# Altium schematic sheet SheetV5.SchDoc
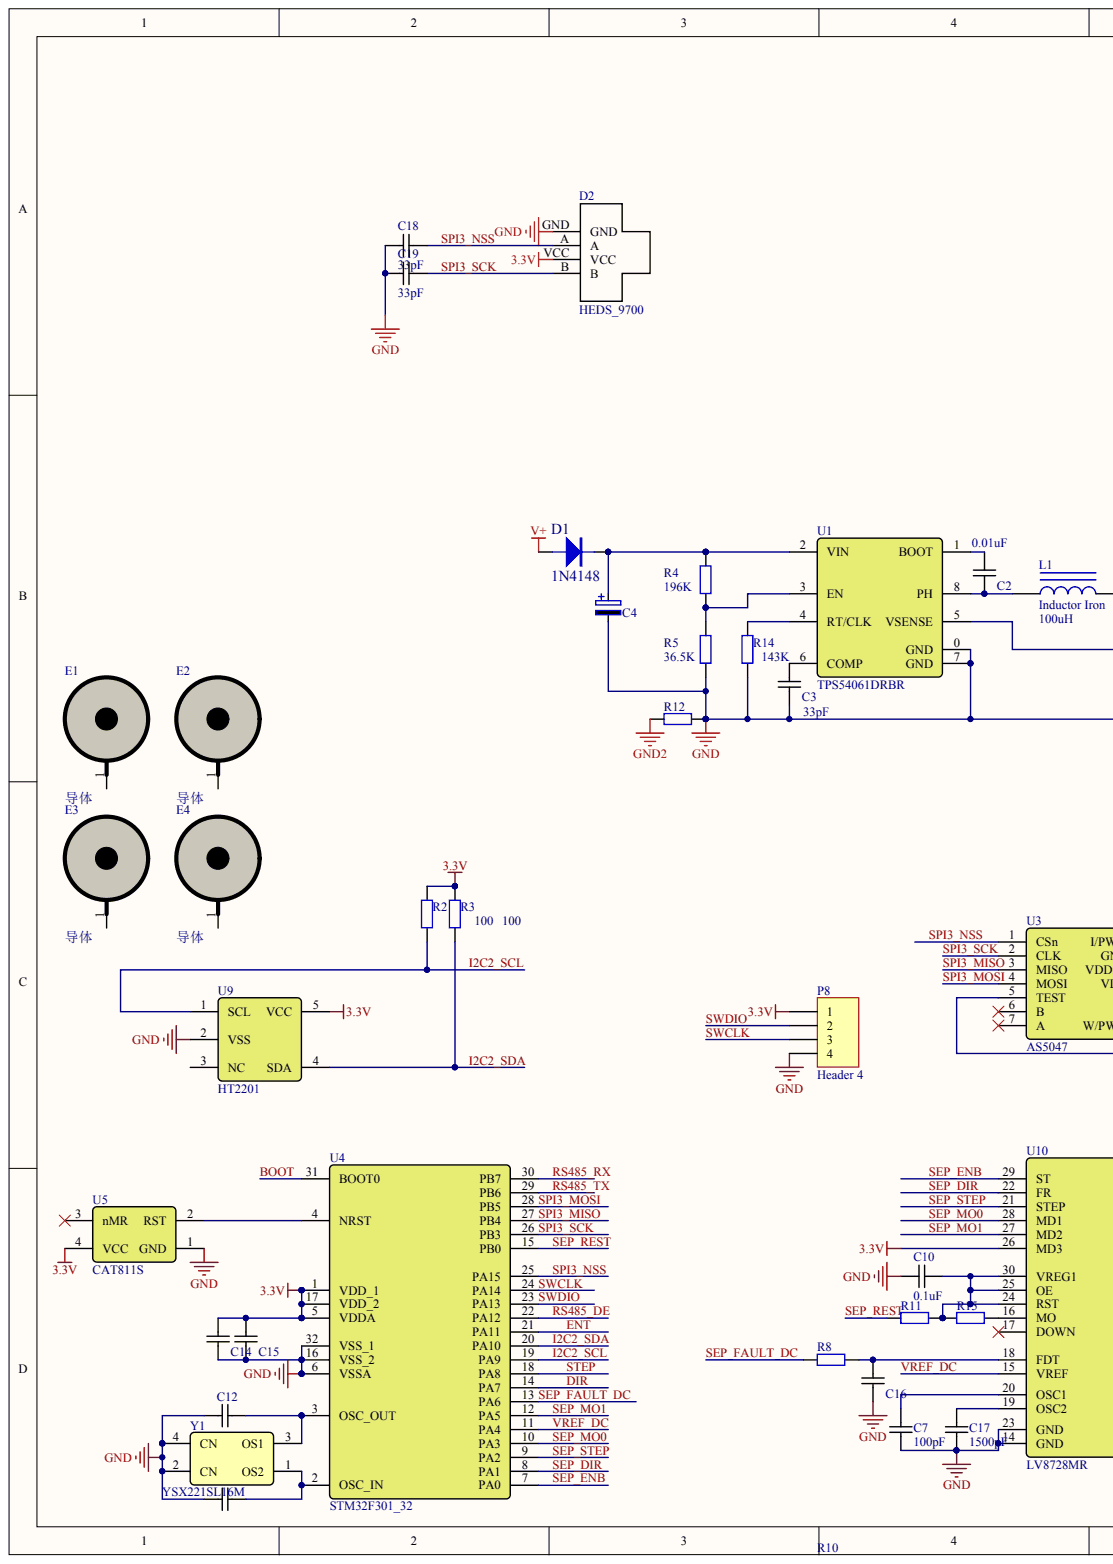
[diagram p0: SheetV5.SchDoc, left]
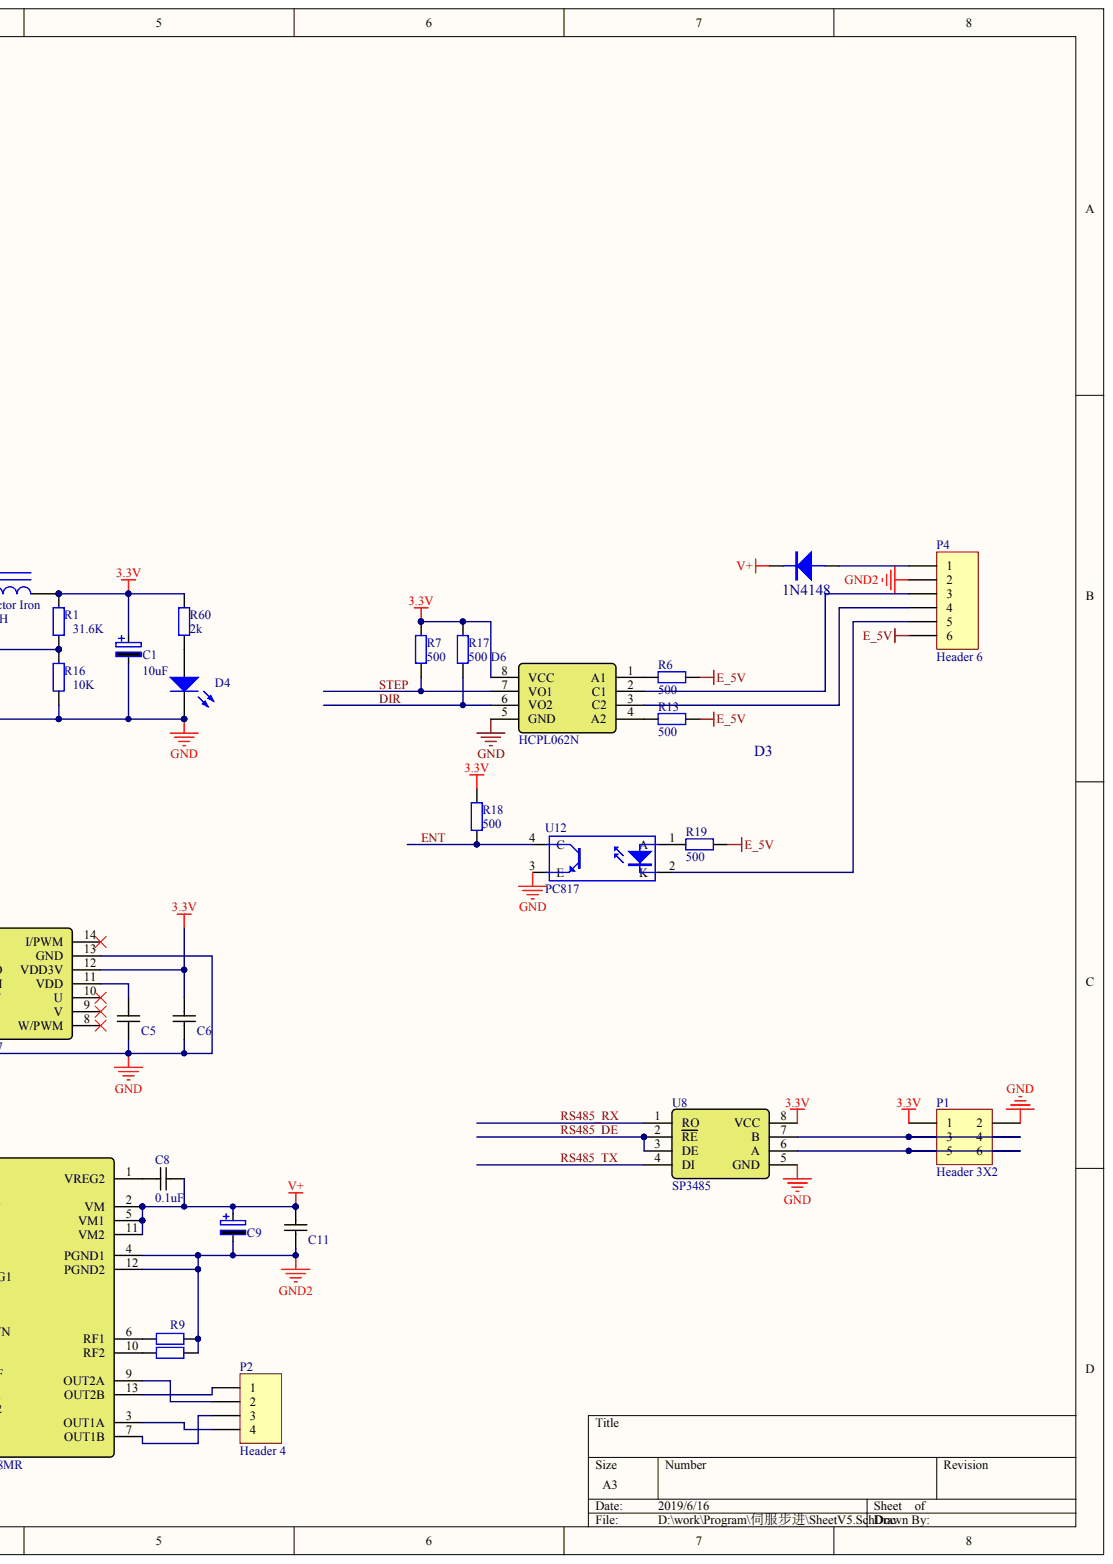
[diagram p1: SheetV5.SchDoc, right]

## Parts
C1  10uF
C2  0.01uF
C3  33pF
C4  100uF  (value not drawn)
C5  Cap  (value not drawn)
C6  Cap  (value not drawn)
C7  100pF
C8  0.1uF
C9  100uF  (value not drawn)
C10  0.1uF
C11  Cap  (value not drawn)
C12  Cap  (value not drawn)
C13  Cap  (value not drawn)
C14  Cap  (value not drawn)
C15  Cap  (value not drawn)
C16  Cap  (value not drawn)
C17  1500pF  value 100pF
C18  33pF
C19  33pF
D1  1N4148
D2  HEDS_9700
D3  1N4148
D4  LED0  (value not drawn)
D6  HCPL062N
E1  导体
E2  导体
E3  导体
E4  导体
L1  Inductor Iron  value 100uH
P1  Header 3X2
P2  Header 4
P4  Header 6
P8  Header 4
R1  31.6K
R2  Res2_1  (value not drawn)
R3  value 100  (hidden comment: Res2_1)
R4  196K
R5  36.5K
R6  value 500  (hidden comment: Res2_1)
R7  value 500  (hidden comment: Res2_1)
R8  Res2_1  (value not drawn)
R9  Res2_1  (value not drawn)
R10  Res2_1  (value not drawn)
R11  Res2_1  (value not drawn)
R12  Res2_1  (value not drawn)
R13  value 500  (hidden comment: Res2_1)
R14  143K
R15  Res2_1  (value not drawn)
R16  10K
R17  value 500  (hidden comment: Res2_1)
R18  value 500  (hidden comment: Res2_1)
R19  value 500  (hidden comment: Res2_1)
R60  value 2k  (hidden comment: Res2_1)
U1  TPS54061DRBR
U3  AS5047
U4  STM32F301_32
U5  CAT811S
U8  value SP3485  (hidden comment: MAX1487)
U9  HT2201
U10  LV8728MR
U12  PC817
Y1  YSX221SL16M

## Nets
3.3V: C1.A C6.2 C14.2 C15.2 D2.VCC D6.8(VCC) L1.1 P1.1 P8.1 R1.1 R2.1 R3.1 R7.1 R17.2 R18.1 R60.1 U3.12(VDD3V) U4.1(VDD_1) U4.5(VDDA) U4.17(VDD_2) U5.4(VCC) U8.8(VCC) U9.5(VCC) U10.26(MD3)
E_5V: P4.6 R6.2 R13.2 R19.2
GND: C1.C C3.1 C4.C C5.1 C6.1 C7.2 C10.2 C12.1 C13.1 C14.1 C15.1 C16.1 C17.2 C18.2 C19.2 D2.GND D4.C D6.5(GND) P1.2 P8.4 R5.2 R12.1 R14.2 R16.2 U1.0(GND) U1.7(GND) U3.5(TEST) U3.13(GND) U4.6(VSSA) U4.16(VSS_2) U4.32(VSS_1) U5.1(GND) U8.5(GND) U9.2(VSS) U10.14(GND) U10.23(GND) U12.3(E) Y1.2(CN) Y1.4(CN)
GND2: C9.C C11.1 P4.2 R9.1 R10.1 R12.2 U10.4(PGND1) U10.12(PGND2)
V+: C8.2 C9.A C11.2 D1.A D3.C U10.2(VM) U10.5(VM1) U10.11(VM2)
BOOT: U4.31(BOOT0)
DIR: D6.6(VO2) R17.1 U4.14(PA7)
ENT: R18.2 U4.21(PA11) U12.4(C)
I2C2_SCL: R2.2 U4.19(PA9) U9.1(SCL)
I2C2_SDA: R3.2 U4.20(PA10) U9.4(SDA)
RS485_DE: U4.22(PA12) U8.2(RE) U8.3(DE)
RS485_RX: U4.30(PB7) U8.1(RO)
RS485_TX: U4.29(PB6) U8.4(DI)
SEP_DIR: U4.8(PA1) U10.22(FR)
SEP_ENB: U4.7(PA0) U10.29(ST)
SEP_FAULT_DC: R8.2 U4.13(PA6)
SEP_MO0: U4.10(PA3) U10.28(MD1)
SEP_MO1: U4.12(PA5) U10.27(MD2)
SEP_REST: R11.1 U4.15(PB0)
SEP_STEP: U4.9(PA2) U10.21(STEP)
SPI3_MISO: U3.3(MISO) U4.27(PB4)
SPI3_MOSI: U3.4(MOSI) U4.28(PB5)
SPI3_NSS: C18.1 D2.A U3.1(CSn) U4.25(PA15)
SPI3_SCK: C19.1 D2.B U3.2(CLK) U4.26(PB3)
STEP: D6.7(VO1) R7.2 U4.18(PA8)
SWCLK: P8.3 U4.24(PA14)
SWDIO: P8.2 U4.23(PA13)
VREF_DC: U4.11(PA4) U10.15(VREF)
NetC2_1: C2.1 L1.2 U1.8(PH)
NetC2_2: C2.2 U1.1(BOOT)
NetC3_2: C3.2 U1.6(COMP)
NetC4_A: C4.A D1.C R4.1 U1.2(VIN)
NetC5_2: C5.2 U3.11(VDD)
NetC7_1: C7.1 U10.20(OSC1)
NetC8_1: C8.1 U10.1(VREG2)
NetC10_1: C10.1 R11.2 R15.1 U10.24(RST) U10.25(OE) U10.30(VREG1)
NetC12_2: C12.2 U4.3(OSC_OUT) Y1.3(OS1)
NetC13_2: C13.2 U4.2(OSC_IN) Y1.1(OS2)
NetC16_2: C16.2 R8.1 U10.18(FDT)
NetC17_1: C17.1 U10.19(OSC2)
NetD3_A: D3.A P4.1
NetD4_A: D4.A R60.2
NetD6_1: D6.1(A1) R6.1
NetD6_2: D6.2(C1) P4.3
NetD6_3: D6.3(C2) P4.4
NetD6_4: D6.4(A2) R13.1
NetP1_3: P1.3 P1.4 U8.7(B)
NetP1_5: P1.5 P1.6 U8.6(A)
NetP2_1: P2.1 U10.13(OUT2B)
NetP2_2: P2.2 U10.9(OUT2A)
NetP2_3: P2.3 U10.7(OUT1B)
NetP2_4: P2.4 U10.3(OUT1A)
NetP4_5: P4.5 U12.2(K)
NetR1_2: R1.2 R16.1 U1.5(VSENSE)
NetR4_2: R4.2 R5.1 U1.3(EN)
NetR9_2: R9.2 U10.6(RF1)
NetR10_2: R10.2 U10.10(RF2)
NetR14_1: R14.1 U1.4(RT/CLK)
NetR15_2: R15.2 U10.16(MO)
NetR19_1: R19.1 U12.1(A)
NetU4_4: U4.4(NRST) U5.2(RST)

Not connected: E1 1; E2 1; E3 1; E4 1; U3 6(B) 7(A) 8(W/PWM) 9(V) 10(U) 14(I/PWM); U5 3(nMR); U9 3(NC); U10 17(DOWN)

## Library data of the parts
C2 C3 C5 C6 C8 C10 C11 C15 C16 C18 C19 = (no symbol name): Value: 100pF; 单位: PCS; 封装: 0805; 类别: 贴片电容; 规格: +-10% / 50V; 名称: 100nF; Published: 8-Jun-2000; LatestRevisionDate: 17-Jul-2002; LatestRevisionNote: Re-released for DXP Platform.; PackageReference: RAD-0.3; Publisher: Altium Limited
C7 C17 = (no symbol name): 单位: PCS; 封装: 0805; 类别: 贴片电容; 规格: +-10% / 50V; 名称: 100nF; Published: 8-Jun-2000; LatestRevisionDate: 17-Jul-2002; LatestRevisionNote: Re-released for DXP Platform.; PackageReference: RAD-0.3; Publisher: Altium Limited
  C7: Value: 100pF
C12 C13 = (no symbol name): Value: 100pF; 单位: PCS; 封装: 0805; 类别: 贴片电容; 规格: +-10% / 50V; 名称: 20pF; Published: 8-Jun-2000; LatestRevisionDate: 17-Jul-2002; LatestRevisionNote: Re-released for DXP Platform.; PackageReference: RAD-0.3; Publisher: Altium Limited
C14 = (no symbol name): Value: 100pF; 单位: PCS; 封装: 0805; 类别: 贴片电容; 规格: +-10% / 50V; 名称: 10nF; Published: 8-Jun-2000; LatestRevisionDate: 17-Jul-2002; LatestRevisionNote: Re-released for DXP Platform.; PackageReference: RAD-0.3; Publisher: Altium Limited
D1 D3 = (no symbol name): 封装: SOD323; 单位: PCS; 名称: 1N4148
R1 R2 R4 R5 R8-R12 R14-R16 = (no symbol name): Value: 100; 单位: PCS; 封装: 0805; 类别: 贴片电阻; 规格: +-10%; 名称: 1K; Published: 8-Jun-2000; LatestRevisionDate: 17-Jul-2002; LatestRevisionNote: Re-released for DXP Platform.; PackageReference: AXIAL-0.4; Publisher: Altium Limited
R3 R6 R7 R13 R17-R19 = (no symbol name): 单位: PCS; 封装: 0805; 类别: 贴片电阻; 规格: +-10%; 名称: 1K; Published: 8-Jun-2000; LatestRevisionDate: 17-Jul-2002; LatestRevisionNote: Re-released for DXP Platform.; PackageReference: AXIAL-0.4; Publisher: Altium Limited
R60 = (no symbol name): 单位: PCS; 封装: 0805; 类别: 贴片电阻; 规格: +-10%; 名称: 10K; Published: 8-Jun-2000; LatestRevisionDate: 17-Jul-2002; LatestRevisionNote: Re-released for DXP Platform.; PackageReference: AXIAL-0.4; Publisher: Altium Limited
U5 = (no symbol name): 单位: PCS; 封装: SOT-143; 类别: 芯片; 规格: \; 名称: IMP811SEUS
U12 = (no symbol name): Value: 0; 封装: DIP-4; 类别: 光耦; 规格: \; 名称: PS2501; Published: 24-Mar-1999; LatestRevisionDate: 04-Mar-2005; LatestRevisionNote: Sch pins 3 & 4 Swapped.; DatasheetDocument: Nov-2003; PackageReference: DIP-4; Name: name; Type: -; Publisher: Altium Limited
C1 C4 C9 D2 D4 D6 E1-E4 L1 P1 P2 P4 P8 U1 U3 U4 U8-U10 Y1 = (no symbol name)
  D4: Published: 8-Jun-2000; LatestRevisionDate: 08-Jul-2005; LatestRevisionNote: Mech Layer 1 removed.; PackageReference: LED-0
  L1: Published: 24-Mar-1999; LatestRevisionDate: 17-Jul-2002; LatestRevisionNote: Re-released for DXP Platform.; PackageReference: AXIAL-0.9
  P1 P2 P4 P8: LatestRevisionDate: 17-Jul-2002; LatestRevisionNote: Re-released for DXP Platform.
  D4 L1 P1 P2 P4 P8: Publisher: Altium Limited
